# Altium schematic sheet 230801_BESKONT_LT.SchDoc
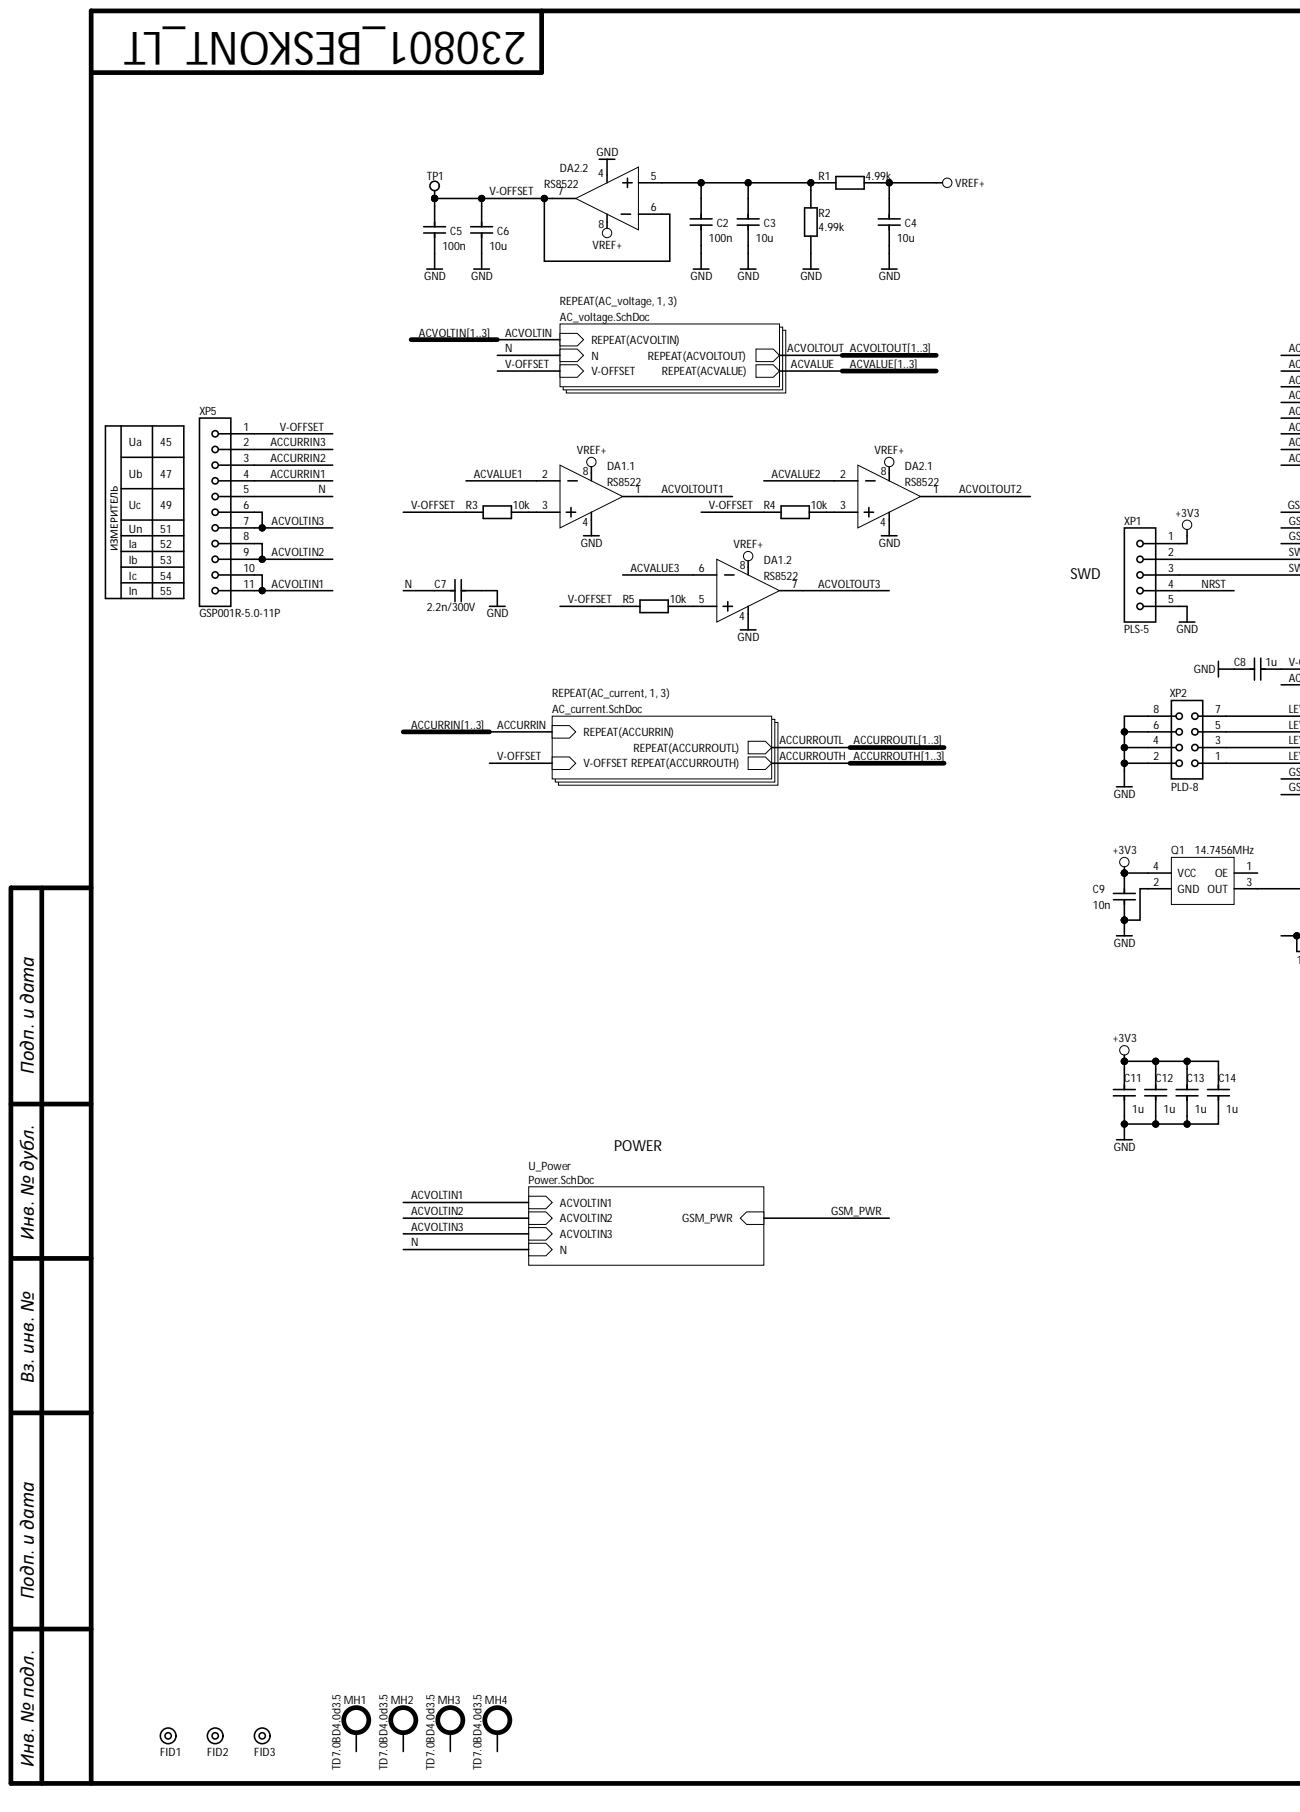
[diagram p0: 230801_BESKONT_LT.SchDoc, left]
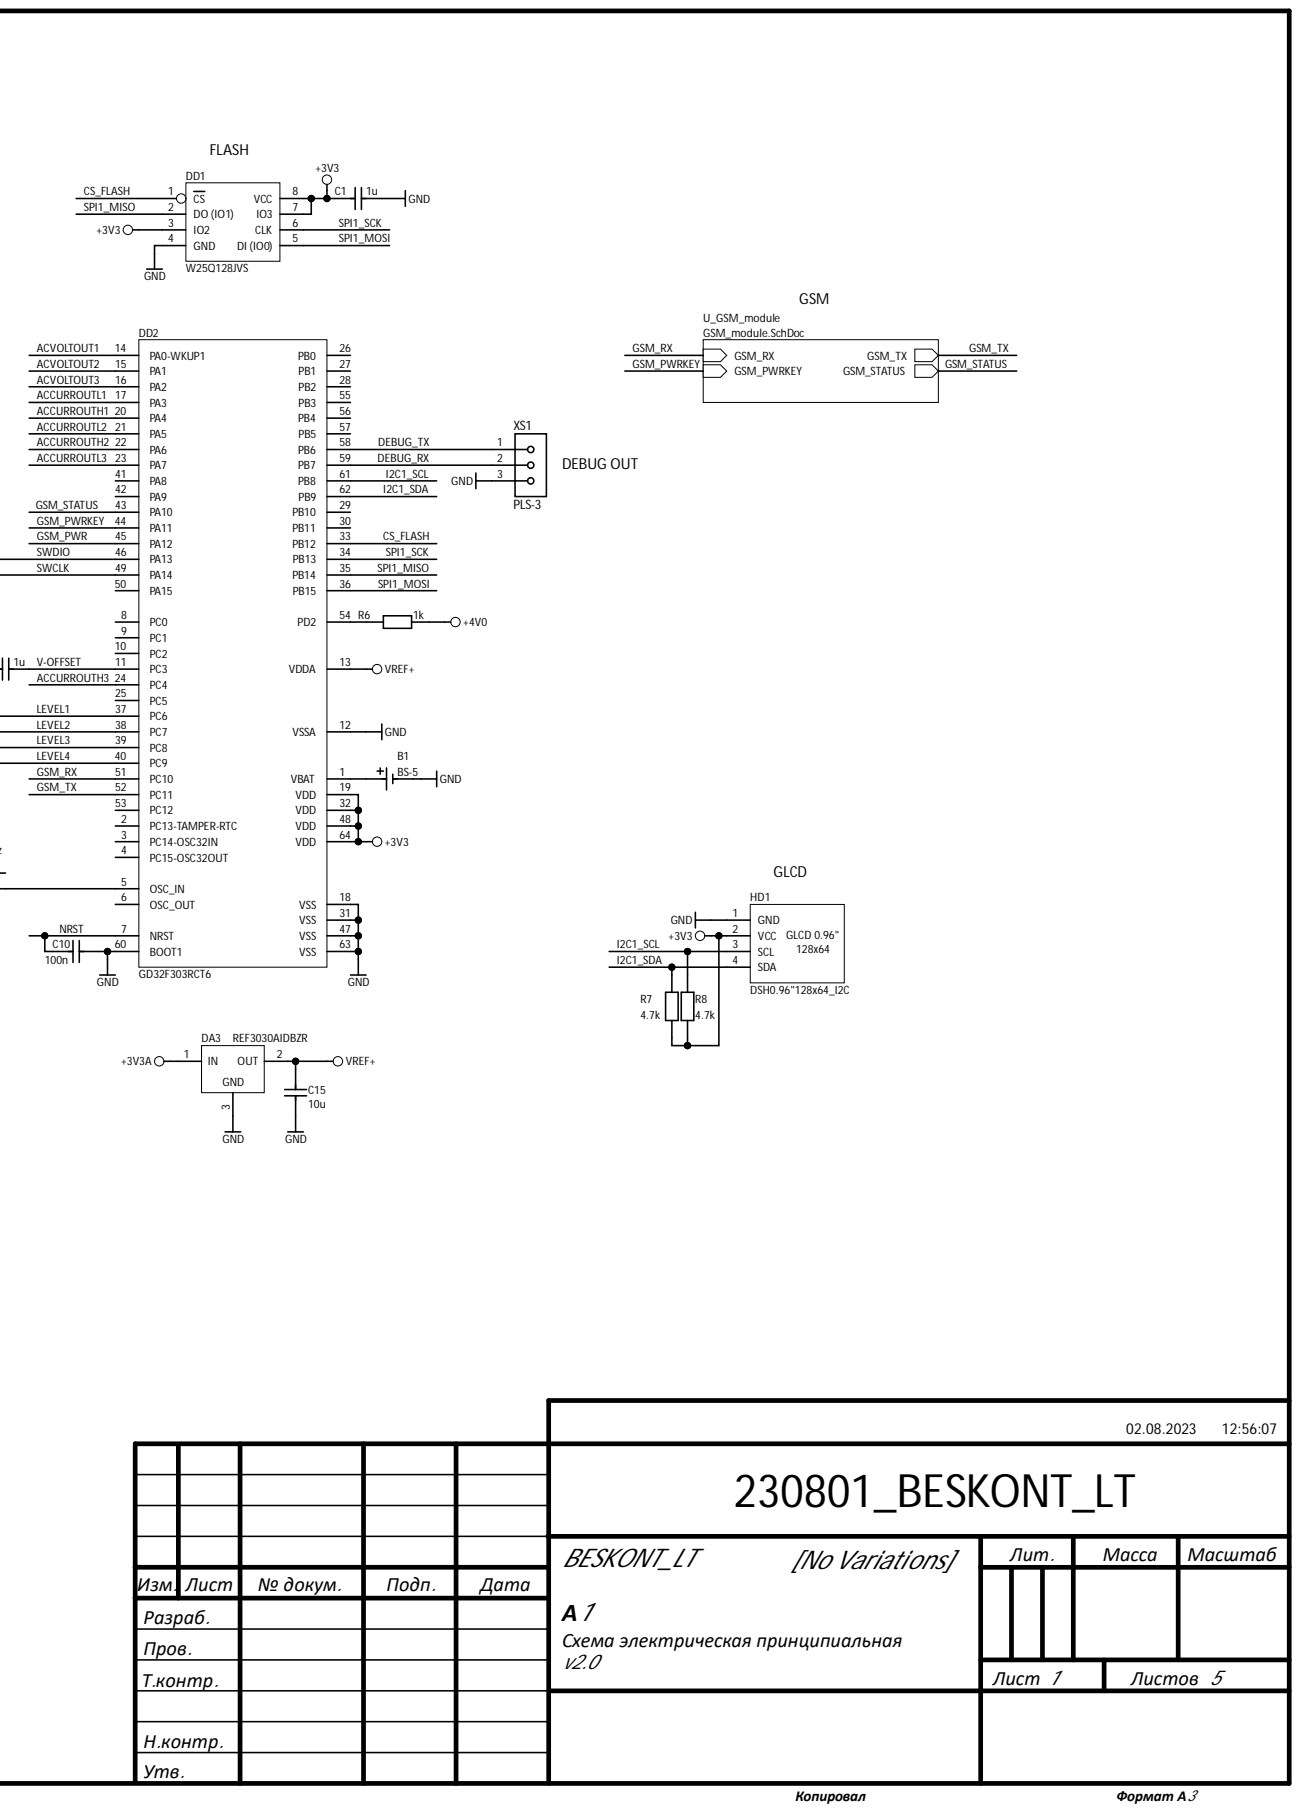
[diagram p1: 230801_BESKONT_LT.SchDoc, right]

## Parts
B1  BS-5  [BS-5]
C1  1u  [C0603H08N1608]
C2  100n  [C0603H08N1608]
C3  10u  [C0603H08N1608]
C4  10u  [C0603H08N1608]
C5  100n  [C0603H08N1608]
C6  10u  [C0603H08N1608]
C7  2.2n/300V  [C_MKP-W4.0H9.0L13.0F10.0]
C8  1u  [C0603H08N1608]
C9  10n  [C0603H08N1608]
C10  100n  [C0603H08N1608]
C11  1u  [C0603H08N1608]
C12  1u  [C0603H08N1608]
C13  1u  [C0603H08N1608]
C14  1u  [C0603H08N1608]
C15  10u  [C0603H08N1608]
DA1  RS8522  [SOIC8_N]  (parts .1,.2)
DA2  RS8522  [SOIC8_N]  (parts .1,.2)
DA3  REF3030AIDBZR  [SOT23_TO-236]
DD1  W25Q128JVS  [SOIC8_150-208N]
DD2  GD32F303RCT6  [LQFP-64_SOT314-2]
FID1  FID_SIGN_D100_R2R  [FID_SIGN_D100_R2R]  (value not drawn)
FID2  FID_SIGN_D100_R2R  [FID_SIGN_D100_R2R]  (value not drawn)
FID3  FID_SIGN_D100_R2R  [FID_SIGN_D100_R2R]  (value not drawn)
HD1  DSH0.96"128x64_I2C  [GLCD_128x64_I2C]
MH1  TD7.0BD4.0d3.5  [M_HOLE_TD7.0xBD4.0x3.5]  (no BOM)
MH2  TD7.0BD4.0d3.5  [M_HOLE_TD7.0xBD4.0x3.5]  (no BOM)
MH3  TD7.0BD4.0d3.5  [M_HOLE_TD7.0xBD4.0x3.5]  (no BOM)
MH4  TD7.0BD4.0d3.5  [M_HOLE_TD7.0xBD4.0x3.5]  (no BOM)
Q1  14.7456MHz  [QC-3.2x2.5]
R1  4.99k  [R0603H05N1608]
R2  4.99k  [R0603H05N1608]
R3  10k  [R0603H05N1608]
R4  10k  [R0603H05N1608]
R5  10k  [R0603H05N1608]
R6  1k  [R0603H05N1608]
R7  4.7k  [R0603H05N1608]
R8  4.7k  [R0603H05N1608]
TP1  Test Pin  [Testpoint_Hole-d1xD3.2w]  (value not drawn)  (no BOM)
XP1  PLS-5  [PLS-5]
XP2  PLD-8  [PLD-8]
XP5  GSP001R-5.0-11P  [GSP001R-5.0-11P]
XS1  PLS-3  [PLS2-3_2_NO_BODY]

## Nets
+3V3: C1.2 C9.2 C11.2 C12.2 C13.2 C14.2 DD1.3(IO2) DD1.7(IO3) DD1.8(VCC) DD2.19(VDD) DD2.32(VDD) DD2.48(VDD) DD2.64(VDD) HD1.2(VCC) Q1.4(VCC) R7.1 R8.1 XP1.1
+3V3A: DA3.1
+4V0: R6.1
GND: B1.2 C1.1 C2.2 C3.1 C4.1 C5.2 C6.1 C7.1 C8.1 C9.1 C10.2 C11.1 C12.1 C13.1 C14.1 C15.1 DA1.4 DA2.4 DA3.3 DD1.4(GND) DD2.12(VSSA) DD2.18(VSS) DD2.31(VSS) DD2.47(VSS) DD2.60(BOOT1) DD2.63(VSS) HD1.1(GND) Q1.2(GND) R2.1 XP1.5 XP2.2 XP2.4 XP2.6 XP2.8 XS1.3
VREF+: C4.2 C15.2 DA1.8 DA2.8 DA3.2 DD2.13(VDDA) R1.2
ACCURRIN1: XP5.4  [also labelled ACCURRIN]
ACCURRIN2: XP5.3  [also labelled ACCURRIN]
ACCURRIN3: XP5.2  [also labelled ACCURRIN]
ACCURROUTH1: DD2.20(PA4)  [also labelled ACCURROUTH]
ACCURROUTH2: DD2.22(PA6)  [also labelled ACCURROUTH]
ACCURROUTH3: DD2.24(PC4)  [also labelled ACCURROUTH]
ACCURROUTL1: DD2.17(PA3)  [also labelled ACCURROUTL]
ACCURROUTL2: DD2.21(PA5)  [also labelled ACCURROUTL]
ACCURROUTL3: DD2.23(PA7)  [also labelled ACCURROUTL]
ACVALUE1: DA1.2  [also labelled ACVALUE]
ACVALUE2: DA2.2  [also labelled ACVALUE]
ACVALUE3: DA1.6  [also labelled ACVALUE]
ACVOLTIN1: XP5.10 XP5.11  [also labelled ACVOLTIN]
ACVOLTIN2: XP5.8 XP5.9  [also labelled ACVOLTIN]
ACVOLTIN3: XP5.6 XP5.7  [also labelled ACVOLTIN]
ACVOLTOUT1: DA1.1 DD2.14(PA0-WKUP1)  [also labelled ACVOLTOUT]
ACVOLTOUT2: DA2.1 DD2.15(PA1)  [also labelled ACVOLTOUT]
ACVOLTOUT3: DA1.7 DD2.16(PA2)  [also labelled ACVOLTOUT]
CS_FLASH: DD1.1(CS) DD2.33(PB12)
DEBUG_RX: DD2.59(PB7) XS1.2
DEBUG_TX: DD2.58(PB6) XS1.1
GSM_PWR: DD2.45(PA12)
GSM_PWRKEY: DD2.44(PA11)
GSM_RX: DD2.51(PC10)
GSM_STATUS: DD2.43(PA10)
GSM_TX: DD2.52(PC11)
I2C1_SCL: DD2.61(PB8) HD1.3(SCL) R8.2
I2C1_SDA: DD2.62(PB9) HD1.4(SDA) R7.2
LEVEL1: DD2.37(PC6) XP2.7
LEVEL2: DD2.38(PC7) XP2.5
LEVEL3: DD2.39(PC8) XP2.3
LEVEL4: DD2.40(PC9) XP2.1
N: C7.2 XP5.5
NRST: C10.1 DD2.7(NRST) XP1.4
SPI1_MISO: DD1.2(DO_(IO1)) DD2.35(PB14)
SPI1_MOSI: DD1.5(DI_(IO0)) DD2.36(PB15)
SPI1_SCK: DD1.6(CLK) DD2.34(PB13)
SWCLK: DD2.49(PA14) XP1.3
SWDIO: DD2.46(PA13) XP1.2
V-OFFSET: C5.1 C6.2 C8.2 DA2.6 DA2.7 DD2.11(PC3) R3.1 R4.1 R5.1 TP1.1 XP5.1
NetB1_1: B1.1 DD2.1(VBAT)
NetC2_1: C2.1 C3.2 DA2.5 R1.1 R2.2
NetDA1_3: DA1.3 R3.2
NetDA1_5: DA1.5 R5.2
NetDA2_3: DA2.3 R4.2
NetDD2_2: DD2.2(PC13-TAMPER-RTC)
NetDD2_3: DD2.3(PC14-OSC32IN)
NetDD2_4: DD2.4(PC15-OSC32OUT)
NetDD2_5: DD2.5(OSC_IN) Q1.3(OUT)
NetDD2_6: DD2.6(OSC_OUT)
NetDD2_8: DD2.8(PC0)
NetDD2_9: DD2.9(PC1)
NetDD2_10: DD2.10(PC2)
NetDD2_25: DD2.25(PC5)
NetDD2_26: DD2.26(PB0)
NetDD2_27: DD2.27(PB1)
NetDD2_28: DD2.28(PB2)
NetDD2_29: DD2.29(PB10)
NetDD2_30: DD2.30(PB11)
NetDD2_41: DD2.41(PA8)
NetDD2_42: DD2.42(PA9)
NetDD2_50: DD2.50(PA15)
NetDD2_53: DD2.53(PC12)
NetDD2_54: DD2.54(PD2) R6.2
NetDD2_55: DD2.55(PB3)
NetDD2_56: DD2.56(PB4)
NetDD2_57: DD2.57(PB5)
NetFID1_1: FID1.1
NetFID2_1: FID2.1
NetFID3_1: FID3.1
NetMH1_0: MH1.0
NetMH2_0: MH2.0
NetMH3_0: MH3.0
NetMH4_0: MH4.0
NetQ1_1: Q1.1(OE)

## Library data of the parts
B1 = BATT_CR2032_PLAST_DIP: Polar Capasitor; Database Table Name: C; Description PDF: Compact вip contacts for cell CR2032 (d=22 mm, h=17.8mm); Description Short: Держатель батареи; TypeComp: Конденсатор; Vendor 1: Memory Protection Devices, Inc. (batteryholders.com); Vendor 2: KLS ELECTRONIC CO.LTD (klsele.com); Vendor 2 PN: KLS5-CR2032-04; Component Kind: Standard; Global Link: BATT_CR2032_PLAST_DIP; Library Name: Components.DbLib; Modify: 18.03.2023; ValueSCH: BS-5; Vendor 1 PN: BS-5
C1 C8 C11-C14 = CC_1u0_0603_50_X5R_10_H08: Non Polar Ceramic Capasitor; Database Table Name: C; Description PDF: Chip Capasitor 0603[1608] - 1uF-50V-X5R-10%; Description Short: SMD-конденсатор; Package 1: 0603_H08; TypeComp: Конденсатор; Vendor 1: Samsung (www.sem.samsung.com); Vendor 1 PN: CL10A105KB8NNNC; Vendor 2: muRata (www.murata.com); Vendor 2 PN: GRM188R61H105KAALD; Vendor 3: TDK (www.tdk-electronics.tdk.com); Vendor 3 PN: C1608X5R1H105K080AB; Vendor 4: Yageo Corporation (www.yageo.com); Vendor 4 PN: CC0603KRX5R9BB105; Component Kind: Standard; Global Link: CC_1u0_0603_50_X5R_10_H08; Library Name: Components.DbLib; Modify: 21.07.2022; Mounting: SMD; Package 2: 0603_H08; Package 3: 0603_H08; Package 4: 0603_H08; ValueSCH: 1u
C2 C5 C10 = CC_100n_0603_50_X7R_10_H08: Non Polar Ceramic Capasitor; Database Table Name: C; Description PDF: Chip Capasitor 0603[1608] - 0.1uF-50V-X7R-10%; Description Short: SMD-конденсатор; Package 1: 0603_H08; TypeComp: Конденсатор; Vendor 1: Samsung (www.sem.samsung.com); Vendor 1 PN: CL10B104KB8NNN; Vendor 2: Hitano (www.hitano.com.tw); Vendor 2 PN: 0603B104K500; Vendor 3: Kemet (www.kemet.com); Vendor 3 PN: C0603C104K5R; Component Kind: Standard; Global Link: CC_100n_0603_50_X7R_10_H08; Library Name: Components.DbLib; Modify: 08.03.2010; Mounting: SMD; Package 2: 0603_H08; Package 3: 0603_H08; ValueSCH: 100n
C3 C4 C6 C15 = CC_10u_0603_10_X5R_10_H08: Non Polar Ceramic Capasitor; Database Table Name: C; Description PDF: Chip Capasitor 0603[1608] - 10uF-10V-X5R-10%; Description Short: SMD-конденсатор; Package 1: 0603_H08; TypeComp: Конденсатор; Vendor 1: Samsung (www.sem.samsung.com); Vendor 1 PN: CL10A106KP8NNNC; Vendor 2: muRata (www.murata.com); Vendor 2 PN: GRM188R61A106KE69D; Vendor 3: Yageo Corporation (www.yageo.com); Vendor 3 PN: CC0603KRX5R6BB106; Component Kind: Standard; Global Link: CC_10u_0603_10_X5R_10_H08; Library Name: Components.DbLib; Modify: 31.01.2020; Mounting: SMD; Package 2: 0603_H08; Package 3: 0603_H08; ValueSCH: 10u
C7 = CF_2.2n_300V_20%: Non Polar Ceramic Capasitor; Database Table Name: C; Description PDF: EMI Suppression Capacitors Y2 class (MKP), Film Capacitors, 2.2nF, AC 300V, 20%, 85C  Aluminum Capacitors Radial Style; Description Short: Конденсатор ПЭТ; Package 1: W4.0H9.0L13.0F10.0; TypeComp: Конденсатор; Vendor 1: TDK (www.tdk-electronics.tdk.com); Vendor 1 PN: B32021A3222M; Vendor 2: Vishay Intertechnology, Inc. (www.vishay.com); Vendor 2 PN: BFC233868396; Component Kind: Standard; Global Link: CF_2.2n_300V_20%; Library Name: Components.DbLib; Modify: 28.02.2023; Package 2: W4.0H9.0L13.0F10.0; ValueSCH: 2.2n/300V
C9 = CC_10n_0603_50_X7R_10_H08: Non Polar Ceramic Capasitor; Database Table Name: C; Description PDF: Chip Capasitor 0603[1608] - 0.01uF-50V-X7R-10%; Description Short: SMD-конденсатор; Package 1: 0603_H08; TypeComp: Конденсатор; Vendor 1: Samsung (www.sem.samsung.com); Vendor 1 PN: CL10B103KB8NNN; Vendor 2: Hitano (www.hitano.com.tw); Vendor 2 PN: 0603B103K500; Vendor 3: Kemet (www.kemet.com); Vendor 3 PN: C0603C103K5R; Component Kind: Standard; Global Link: CC_10n_0603_50_X7R_10_H08; Library Name: Components.DbLib; Modify: 08.03.2010; Mounting: SMD; Package 2: 0603_H08; Package 3: 0603_H08; ValueSCH: 10n
DA1 DA2 = RS8522XK: Low-power dual operational amplifier; Database Table Name: D; Description PDF: Zero-Drift, Rail-to-Rail I/O CMOS Operational Amplifi; Description Short: микросхема, операционный усилитель; Package 1: SO-8; Vendor 1: RUNIC (www.run-ic.com); Component Kind: Standard; Global Link: RS8522XK; Library Name: Components.DbLib; Modify: 18.04.2023; Mounting: SMD; PackageDescription: 8-Pin Small Outline Integrated Circuit 1.27 mm Pitch; ValueSCH: RS8522; Vendor 1 PN: RS8522XK
DA3 = REF3030AIDBZR: 3-pin DC-DC, Ref; Database Table Name: D; Description PDF: Vref=3,0V50ppm/°C Max, 50μA CMOS Voltage Reference; Description Short: Микросхема, опорное напряж.; Package 1: SOT23-3; Vendor 1: Texas Instruments (www.ti.com); Component Kind: Standard; Global Link: REF3030AIDBZR; Library Name: Components.DbLib; Modify: 24.03.2023; Mounting: SMD; ValueSCH: REF3030AIDBZR; Vendor 1 PN: REF3030AIDBZR
DD1 = W25Q128JVS: General-purpose single bipolar timers; Database Table Name: D; Description PDF: Serial  Flash  memory (128M-bit) with Dual/Quad  SPI  Cap-Free, NMOS, 250mA Low Dropout Regulator with Reverse Current Protection; Description Short: Микросхема, память Flash; Package 1: SOIC8 208 mil; Package 2: SOIC8 208; Vendor 1: Winbond (winbond.com); Vendor 2: Micron (www.micron.com); Vendor 2 PN: M25P80-VMNx; Vendor 3 PN: M25P80-VMWx; Component Kind: Standard; Global Link: W25Q128JVS; Library Name: Components.DbLib; Modify: 14.04.2023; Mounting: SMD; Package 3: SOIC8 208 mil; ValueSCH: W25Q128JVS; Vendor 1 PN: W25Q128JVS
DD2 = GD32F303RCT6: Ultra-low-power 32-bit MCU ARM-based Cortex-M4, 100-Pin LQFP; PartNumber: STM32F103VxT; Database Table Name: D; Description PDF: Arm®-based Cortex®-M4 32b MCU+FPU, up to 128KB Flash+32KB SRAM, 3 ADCs, 2 DAC ch., 7 comp, 4 PGA, timers, 2.0-3.6 V; Description Short: Микроконтроллер; fmax: 120 MHz; GPIOs: 80; Package 1: LQFP-64, SOT314-2; VDD (max): 3.6 V; VDD (min): 2 V; VDD (typ): 3.3 V; Vendor 1: GigaDevice  (www.gigadevice.com); Vendor 1 PN: GD32F303VCT6; Component Kind: Standard; ComponentLink1Description: Manufacturer URL; ComponentLink1URL: http://www.st.com/; ComponentLink2Description: Datasheet; ComponentLink2URL: https://www.st.com/resource/en/datasheet/stm32f103vg.pdf; Global Link: GD32F303RCT6; Library Name: Components.DbLib; Modify: 03.06.2023; Mounting: SMD; PackageDescription: LQFP64: Plastic Low Profile Quad Flat Package; 64 Leads; Body 12 x 12 x 1.4 mm; PackageReference: SOT407-1; ValueSCH: GD32F303RCT6
FID1-FID3 = FID_SIGN_D100_R2R: Global Fiducial; Database Table Name: M; Description PDF: Fiducial Sign, diameter 1.0mm, Expansion Value R2R; Description Short: Реперный знак; NoteBOM: Not to buy; Vendor 1 PN: No Vendor; Component Kind: Standard; Global Link: FID_SIGN_D100_R2R; Library Name: Components.DbLib; Modify: 15.11.2016; Mounting: SMD; TypeComp: Реперный знак; ValueSCH: FID_SIGN_D100_R2R
HD1 = DSH0.96"128x64_I2C: DC Quadro Input Photocoupler; Database Table Name: H; Description PDF: LCD-shild 0.96", 128x64, I2C; Description Short: LCD шилд; TypeComp: Оптопара; ValueBOM: PC3QH67Q; Component Kind: Standard; Global Link: DSH0.96"128x64_I2C; Library Name: Components.DbLib; Modify: 28.03.2023; ValueSCH: DSH0.96"128x64_I2C; Publisher: TRIMA Ltd.
MH1-MH4 = M_HOLE_TD7.0xBD4.0x3.5: Montage Hole; Database Table Name: M; Description PDF: Mounting Hole, Pad TopD=7.0mm, BotD=4.0mm, Hole d=3.5mm; Description Short: Монтажное отверстие; NoteBOM: Not to buy; Vendor 1 PN: No Vendor; Global Link: M_HOLE_TD7.0xBD4.0x3.5; Library Name: Components.DbLib; Modify: 19.03.2023; ValueSCH: TD7.0BD4.0d3.5
Q1 = EB13E2H2H-14.7456M-TR: Crystal Oscillator; Database Table Name: Q; Description PDF: Crystal Oscillator SMD 14.7456MHz 15pF 50ppm, (8.2*3.8*0.9mm); Description Short: Кварцевый генератор; TypeComp: Кварц. резонатор; Vendor 1: ECLIPTEK (www.ecliptek.com); Vendor 2: Epson (www.eea.epson.com); Vendor 2 PN: SG-8002CE 14.745600MHZ SCB; Component Kind: Standard; Global Link: EB13E2H2H-14.7456M-TR; Library Name: Components.DbLib; Modify: 15.03.2023; Mounting: SMD; ValueSCH: 14.7456MHz; Vendor 1 PN: EB13E2H2H-14.7456M-TR
R1 R2 = RC_4K99_0603_01%_25PPM: Resistor; Database Table Name: R; Description PDF: Chip Resistor 0603[1608] - 4.99KOHM-1/10W-0.1%, 75V, 25PPM; Description Short: SMD-резистор; Package 1: 1608 (0603); TypeComp: Резистор; Vendor 1: Yageo Corporation (www.yageo.com); Vendor 1 PN: RT0603BRD074K99L; Vendor 2: Panasonic/Matsushita Electric Industrial (www.panasonic.net); Vendor 2 PN: ERA-3AEB4991V; Component Kind: Standard; Global Link: RC_4K99_0603_01%_25PPM; Library Name: Components.DbLib; Modify: 18.04.2023; Mounting: SMD; Package 2: 1608 (0603); Package 3: 1608 (0603); Package 4: 1608 (0603); ValueSCH: 4.99k
R3-R5 = RC_10K_0603_01%_25PPM: Resistor; Database Table Name: R; Description PDF: Chip Resistor 0603[1608] - 10KOHM-1/10W-0.1%, 75V, 25PPM; Description Short: SMD-резистор; Package 1: 1608 (0603); TypeComp: Резистор; Vendor 1: Yageo Corporation (www.yageo.com); Vendor 1 PN: RT0603BRD0710KL; Vendor 2: Panasonic/Matsushita Electric Industrial (www.panasonic.net); Vendor 2 PN: ERA-3AEB103V  ERA-3AEB103V; Vendor 3: Vishay Intertechnology, Inc. (www.vishay.com); Vendor 3 PN: TNPW060310K0BEEA; Component Kind: Standard; Global Link: RC_10K_0603_01%_25PPM; Library Name: Components.DbLib; Modify: 18.04.2023; Mounting: SMD; Package 2: 1608 (0603); Package 3: 1608 (0603); Package 4: 1608 (0603); ValueSCH: 10k
R6 = RC_1K0_0603_1%: Resistor; Database Table Name: R; Description PDF: Chip Resistor 0603[1608] - 1.0KOHM-1/10W-1%; Description Short: SMD-резистор; Package 1: 1608 (0603); TypeComp: Резистор; Vendor 1: Yageo Corporation (www.yageo.com); Vendor 1 PN: RC0603FR-071KL; Vendor 2: Viking (www.viking.com.tw); Vendor 2 PN: CR-03FL7---1K; Vendor 3: Samsung (www.sem.samsung.com); Vendor 3 PN: RC1608F102CS; Vendor 4: Vishay Intertechnology, Inc. (www.vishay.com); Vendor 4 PN: CRCW06031K0FK; Component Kind: Standard; Global Link: RC_1K0_0603_1%; Library Name: Components.DbLib; Modify: 04.06.2020; Mounting: SMD; Package 2: 1608 (0603); Package 3: 1608 (0603); Package 4: 1608 (0603); ValueSCH: 1k
R7 R8 = RC_4K7_0603_1%: Resistor; Database Table Name: R; Description PDF: Chip Resistor 0603[1608] - 4.7KOHM-1/10W-1%; Description Short: SMD-резистор; Package 1: 1608 (0603); TypeComp: Резистор; Vendor 1: Yageo Corporation (www.yageo.com); Vendor 1 PN: RC0603FR-074K7L; Vendor 2: Viking (www.viking.com.tw); Vendor 2 PN: CR-03FL7---4K7; Vendor 3: Samsung (www.sem.samsung.com); Vendor 3 PN: RC1608F472CS; Vendor 4: Vishay Intertechnology, Inc. (www.vishay.com); Vendor 4 PN: CRCW06034K7FK; Component Kind: Standard; Global Link: RC_4K7_0603_1%; Library Name: Components.DbLib; Modify: 07.11.2022; Mounting: SMD; Package 2: 1608 (0603); Package 3: 1608 (0603); Package 4: 1608 (0603); ValueSCH: 4.7k
TP1 = Test Pin d1xD3.2 W: Test point; Database Table Name: X; Description PDF: Test Pin PCB Wite: hole =d1mm, ring=D3.2mm; Description Short: Тест-контакт; Vendor 1: Multicom (www.farnell.com); Vendor 1 PN: TEST-1(W); Vendor 2: Dart (www.dart.ru); Global Link: Test Pin d1xD3.2 W; Library Name: Components.DbLib; Modify: 26.09.2019; ValueSCH: Test Pin; Vendor 2 PN: TEST-1(W)
XP1 = PLS-5: 5-pin Singl Line Plag; Database Table Name: X; Description PDF: 2.54mm Pin Heaser Single Row 05P Straight Type (C=6.0mm D=3.0mm ); Description Short: Штифт; Package 1: C2100-05ASGAS0; Package 2: HD1X05; TypeComp: Разъём; Vendor 1: HSUAN MAO Technology Co.,Ltd (www.hsm.net.tw); Vendor 1 PN: C2100-05ASGAS0(S112); Vendor 2: AUK Contractors Co.,Ltd (www.aukconnector.com); Component Kind: Standard; Global Link: PLS-5; Library Name: Components.DbLib; Modify: 02.02.2023; ValueSCH: PLS-5; Vendor 2 PN: HD1X05
XP2 = PLD-8: 8-pin Duble Line Plag; Database Table Name: X; Description PDF: 2.54mm Pin Header Duble Row 8P Straight Type (C=6.0mm D=3.0mm ); Description Short: Штифт; TypeComp: Соединитель; Vendor 1: HSUAN MAO Technology Co.,Ltd (www.hsm.net.tw); Vendor 1 PN: C2200-08BSGAS; Vendor 2: AUK Contractors Co.,Ltd (www.aukconnector.com); Vendor 2 PN: HD2X08-H; Component Kind: Standard; Global Link: PLD-8; Library Name: Components.DbLib; Modify: 03.02.2023; ValueSCH: PLD-8
XP5 = GSP001R-5.0-11P-11: 10-pin Singl Line Plag; Database Table Name: X; Description PDF: Pluggable terminal blocks right angle, Rated current:20A. Rated voltage:320V, pitch:5.0mm; Description Short: Клемма; TypeComp: Разъём; Vendor 1: GOOSVN (www.goosvn.com); Vendor 2: Degson (www.degson.com); Vendor 2 PN: 2EDGR-5.0-11P-10030001205; Component Kind: Standard; Global Link: GSP001R-5.0-11P-11; Library Name: Components.DbLib; Modify: 26.02.2023; ValueSCH: GSP001R-5.0-11P; Vendor 1 PN: GSP001R-5.0-11P-11
XS1 = PLS-3_NB_2.0: 3-pin Singl Line Socket; Database Table Name: X; Description PDF: 2.0mm Pin Socket Single Row 03P; Description Short: Безкорпусное соединение; NoteBOM: Not to buy; TypeComp: Разъём; Component Kind: Standard; Global Link: PLS-3_NB_2.0; Library Name: Components.DbLib; Modify: 31.05.2020; ValueSCH: PLS-3
